# Altium schematic sheet 19_ATK_RK3588S_MIPI.SchDoc
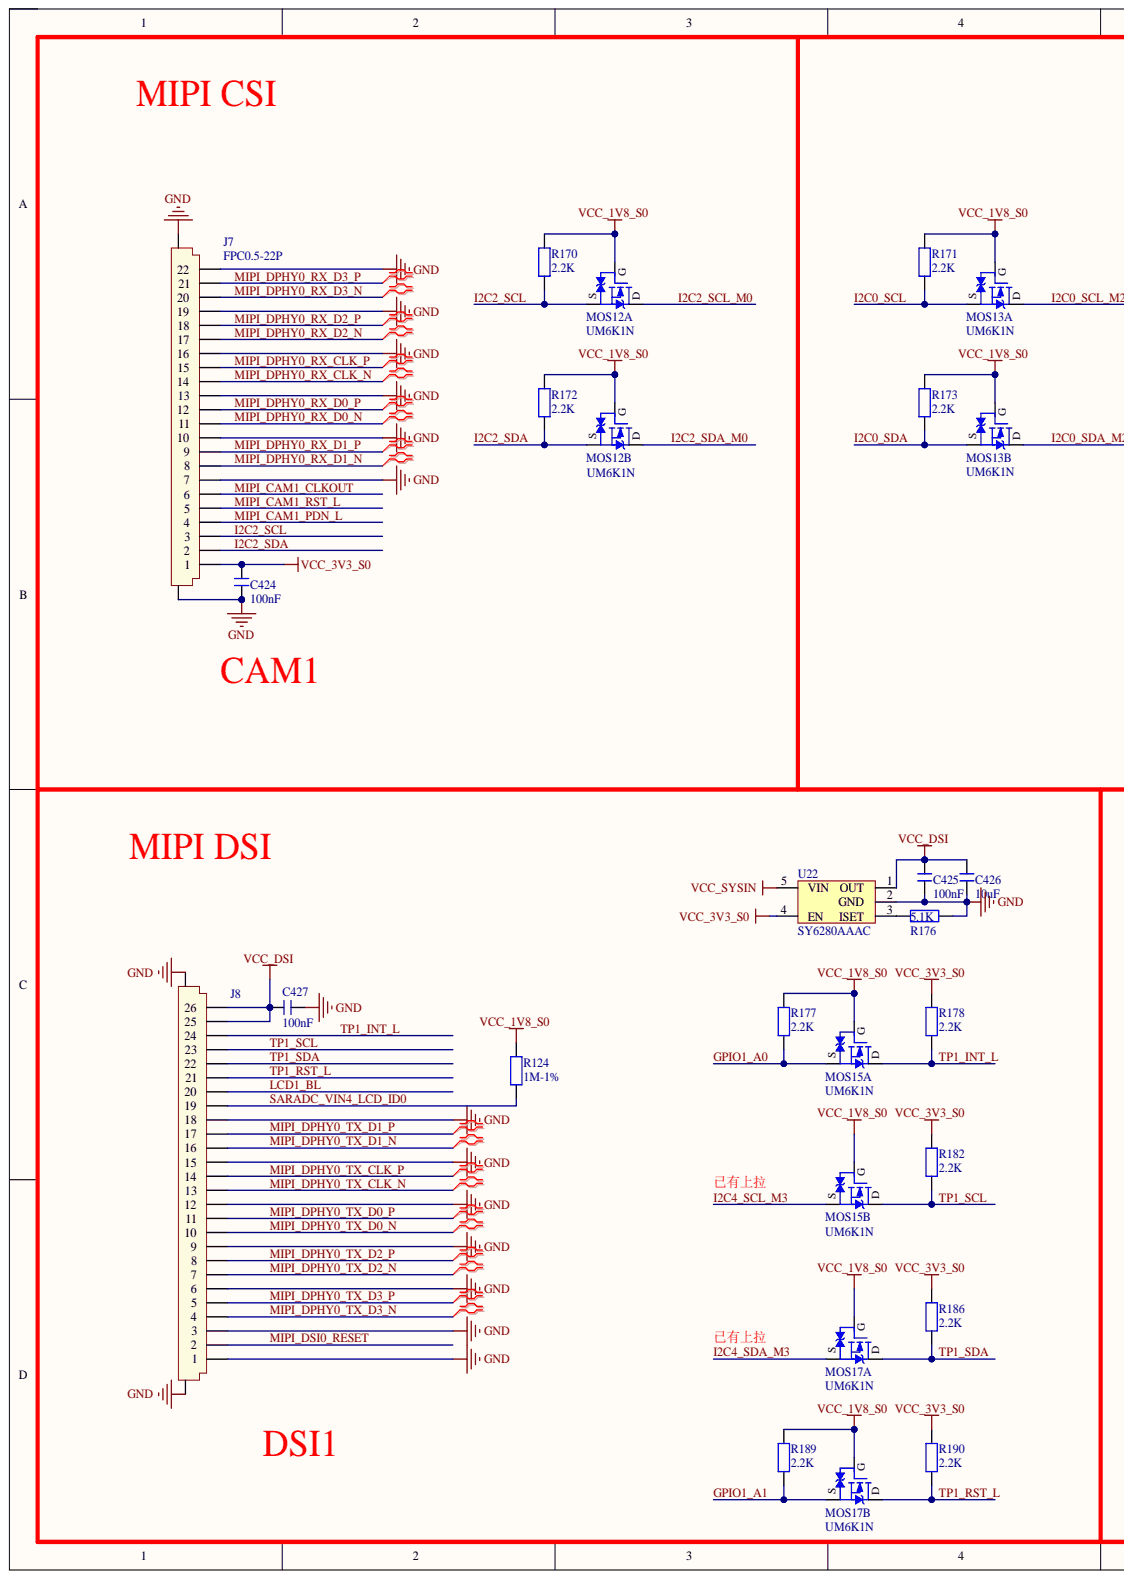
[diagram p0: 19_ATK_RK3588S_MIPI.SchDoc, left]
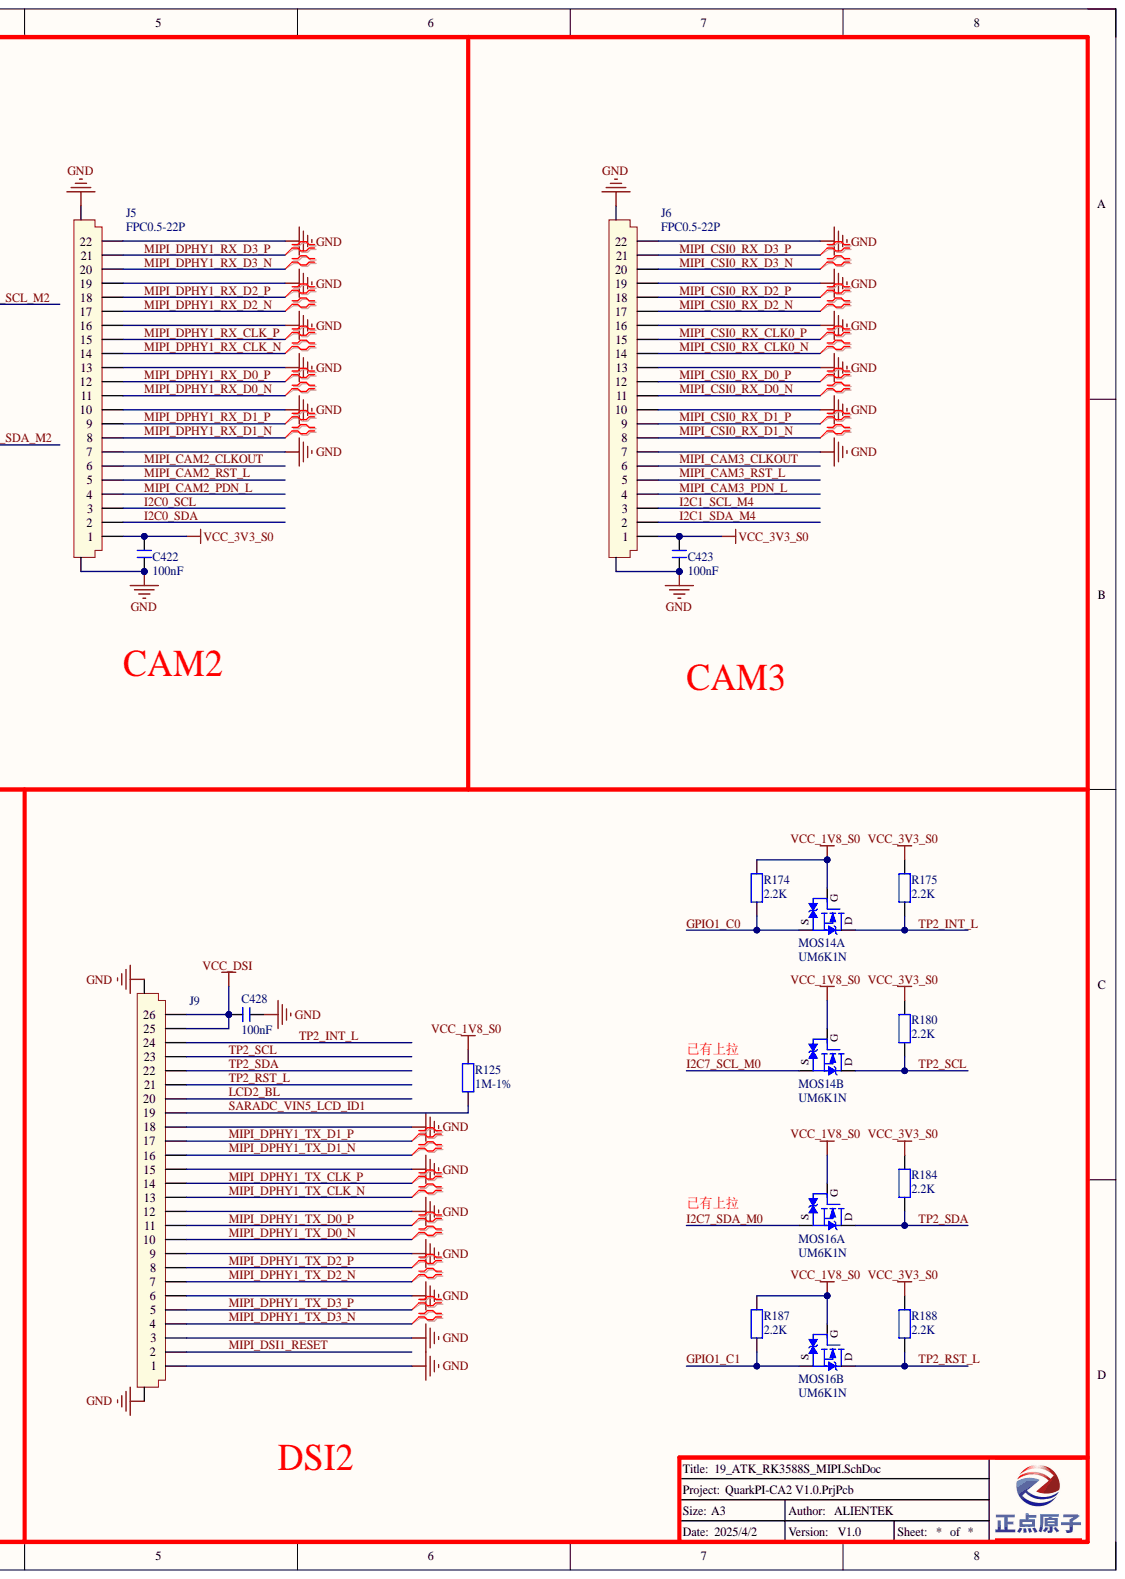
[diagram p1: 19_ATK_RK3588S_MIPI.SchDoc, right]

## Parts
C422  100nF  [C 0201]
C423  100nF  [C 0201]
C424  100nF  [C 0201]
C425  100nF  [C 0201]
C426  10uF  [C 0402]
C427  100nF  [C 0201]
C428  100nF  [C 0201]
J5  FPC0.5-22P  [FPC 0.5 22P V-S]
J6  FPC0.5-22P  [FPC 0.5 22P V-S]
J7  FPC0.5-22P  [FPC 0.5 22P V-S]
J8  FPC0.5-26P  [FPC 0.5 26P H-S]  (value not drawn)
J9  FPC0.5-26P  [FPC 0.5 26P H-S]  (value not drawn)
MOS12  UM6K1N  [SOT363-6L]  (parts A,B)
MOS13  UM6K1N  [SOT363-6L]  (parts A,B)
MOS14  UM6K1N  [SOT363-6L]  (parts A,B)
MOS15  UM6K1N  [SOT363-6L]  (parts A,B)
MOS16  UM6K1N  [SOT363-6L]  (parts A,B)
MOS17  UM6K1N  [SOT363-6L]  (parts A,B)
R124  1M-1%  [R 0402]
R125  1M-1%  [R 0402]
R170  2.2K  [R 0201]
R171  2.2K  [R 0201]
R172  2.2K  [R 0201]
R173  2.2K  [R 0201]
R174  2.2K  [R 0201]
R175  2.2K  [R 0201]
R176  5.1K  [R 0402]
R177  2.2K  [R 0201]
R178  2.2K  [R 0201]
R180  2.2K  [R 0201]
R182  2.2K  [R 0201]
R184  2.2K  [R 0201]
R186  2.2K  [R 0201]
R187  2.2K  [R 0201]
R188  2.2K  [R 0201]
R189  2.2K  [R 0201]
R190  2.2K  [R 0201]
U22  SY6280AAAC  [SOT23-5N]

## Nets
GND: C422.1 C423.1 C424.1 C425.2 C426.2 C427.2 C428.2 J5.0 J5.7 J5.10 J5.13 J5.16 J5.19 J5.22 J6.0 J6.7 J6.10 J6.13 J6.16 J6.19 J6.22 J7.0 J7.7 J7.10 J7.13 J7.16 J7.19 J7.22 J8.0 J8.1 J8.3 J8.6 J8.9 J8.12 J8.15 J8.18 J9.0 J9.1 J9.3 J9.6 J9.9 J9.12 J9.15 J9.18 R176.1 U22.2(GND)
VCC_1V8_S0: MOS12.2 MOS12.5 MOS13.2 MOS13.5 MOS14.2 MOS14.5 MOS15.2 MOS15.5 MOS16.2 MOS16.5 MOS17.2 MOS17.5 R124.2 R125.2 R170.2 R171.2 R172.2 R173.2 R174.2 R177.2 R187.2 R189.2
VCC_3V3_S0: C422.2 C423.2 C424.2 J5.1 J6.1 J7.1 R175.2 R178.2 R180.2 R182.2 R184.2 R186.2 R188.2 R190.2 U22.4(EN)
VCC_DSI: C425.1 C426.1 C427.1 C428.1 J8.25 J8.26 J9.25 J9.26 U22.1(OUT)
VCC_SYSIN: U22.5(VIN)
GPIO1_A0: MOS15.1 R177.1
GPIO1_A1: MOS17.4 R189.1
GPIO1_C0: MOS14.1 R174.1
GPIO1_C1: MOS16.4 R187.1
I2C0_SCL: J5.3 MOS13.1 R171.1
I2C0_SCL_M2: MOS13.6
I2C0_SDA: J5.2 MOS13.4 R173.1
I2C0_SDA_M2: MOS13.3
I2C1_SCL_M4: J6.3
I2C1_SDA_M4: J6.2
I2C2_SCL: J7.3 MOS12.1 R170.1
I2C2_SCL_M0: MOS12.6
I2C2_SDA: J7.2 MOS12.4 R172.1
I2C2_SDA_M0: MOS12.3
I2C4_SCL_M3: MOS15.4
I2C4_SDA_M3: MOS17.1
I2C7_SCL_M0: MOS14.4
I2C7_SDA_M0: MOS16.1
LCD1_BL: J8.20
LCD2_BL: J9.20
MIPI_CAM1_CLKOUT: J7.6
MIPI_CAM1_PDN_L: J7.4
MIPI_CAM1_RST_L: J7.5
MIPI_CAM2_CLKOUT: J5.6
MIPI_CAM2_PDN_L: J5.4
MIPI_CAM2_RST_L: J5.5
MIPI_CAM3_CLKOUT: J6.6
MIPI_CAM3_PDN_L: J6.4
MIPI_CAM3_RST_L: J6.5
MIPI_CSI0_RX_CLK0_N: J6.14
MIPI_CSI0_RX_CLK0_P: J6.15
MIPI_CSI0_RX_D0_N: J6.11
MIPI_CSI0_RX_D0_P: J6.12
MIPI_CSI0_RX_D1_N: J6.8
MIPI_CSI0_RX_D1_P: J6.9
MIPI_CSI0_RX_D2_N: J6.17
MIPI_CSI0_RX_D2_P: J6.18
MIPI_CSI0_RX_D3_N: J6.20
MIPI_CSI0_RX_D3_P: J6.21
MIPI_DPHY0_RX_CLK_N: J7.14
MIPI_DPHY0_RX_CLK_P: J7.15
MIPI_DPHY0_RX_D0_N: J7.11
MIPI_DPHY0_RX_D0_P: J7.12
MIPI_DPHY0_RX_D1_N: J7.8
MIPI_DPHY0_RX_D1_P: J7.9
MIPI_DPHY0_RX_D2_N: J7.17
MIPI_DPHY0_RX_D2_P: J7.18
MIPI_DPHY0_RX_D3_N: J7.20
MIPI_DPHY0_RX_D3_P: J7.21
MIPI_DPHY0_TX_CLK_N: J8.13
MIPI_DPHY0_TX_CLK_P: J8.14
MIPI_DPHY0_TX_D0_N: J8.10
MIPI_DPHY0_TX_D0_P: J8.11
MIPI_DPHY0_TX_D1_N: J8.16
MIPI_DPHY0_TX_D1_P: J8.17
MIPI_DPHY0_TX_D2_N: J8.7
MIPI_DPHY0_TX_D2_P: J8.8
MIPI_DPHY0_TX_D3_N: J8.4
MIPI_DPHY0_TX_D3_P: J8.5
MIPI_DPHY1_RX_CLK_N: J5.14
MIPI_DPHY1_RX_CLK_P: J5.15
MIPI_DPHY1_RX_D0_N: J5.11
MIPI_DPHY1_RX_D0_P: J5.12
MIPI_DPHY1_RX_D1_N: J5.8
MIPI_DPHY1_RX_D1_P: J5.9
MIPI_DPHY1_RX_D2_N: J5.17
MIPI_DPHY1_RX_D2_P: J5.18
MIPI_DPHY1_RX_D3_N: J5.20
MIPI_DPHY1_RX_D3_P: J5.21
MIPI_DPHY1_TX_CLK_N: J9.13
MIPI_DPHY1_TX_CLK_P: J9.14
MIPI_DPHY1_TX_D0_N: J9.10
MIPI_DPHY1_TX_D0_P: J9.11
MIPI_DPHY1_TX_D1_N: J9.16
MIPI_DPHY1_TX_D1_P: J9.17
MIPI_DPHY1_TX_D2_N: J9.7
MIPI_DPHY1_TX_D2_P: J9.8
MIPI_DPHY1_TX_D3_N: J9.4
MIPI_DPHY1_TX_D3_P: J9.5
MIPI_DSI0_RESET: J8.2
MIPI_DSI1_RESET: J9.2
SARADC_VIN4_LCD_ID0: J8.19 R124.1
SARADC_VIN5_LCD_ID1: J9.19 R125.1
TP1_INT_L: J8.24 MOS15.6 R178.1
TP1_RST_L: J8.21 MOS17.3 R190.1
TP1_SCL: J8.23 MOS15.3 R182.1
TP1_SDA: J8.22 MOS17.6 R186.1
TP2_INT_L: J9.24 MOS14.6 R175.1
TP2_RST_L: J9.21 MOS16.3 R188.1
TP2_SCL: J9.23 MOS14.3 R180.1
TP2_SDA: J9.22 MOS16.6 R184.1
NetR176_2: R176.2 U22.3(ISET)

## Library data of the parts
C422-C428 = C: 无极性电容; BOM名称: 无极性贴片电容; 版权归属: 正点原子; Component Kind: Standard; Library Name: 正点原子封装库V1.0.IntLib; 学习资源: www.openedv.com
J5-J7 = FPC-22P: FPC连接器; Parameter: 接口器件; 版权归属: 正点原子; BOM名称: FPC连接器; Component Kind: Standard; 学习资源: www.openedv.com; Library Name: AtomPi-CA1 V1.1.IntLib
J8 J9 = FPC-26P: FPC连接器; Parameter: 接口器件; 版权归属: 正点原子; BOM名称: FPC连接器; Component Kind: Standard; 学习资源: www.openedv.com; Library Name: AtomPi-CA1 V1.1.IntLib
MOS12-MOS17 = DUAL NMOS: NMOS; BOM名称: N沟道场效应管; Parameter: MOS管; 版权归属: 正点原子; Component Kind: Standard; Library Name: MOS管.SchLib; 学习资源: www.openedv.com
R124 R125 R170-R178 R180 R182 R184 R186-R190 = R: 电阻; BOM名称: 贴片电阻; 版权归属: 正点原子; Component Kind: Standard; Library Name: 正点原子封装库V1.0.IntLib; Parameter: 电阻; 学习资源: www.openedv.com
U22 = MT9700HT5: 功率开关(5.5V-2A); BOM名称: 稳压芯片; Parameter: 芯片; 版权归属: 正点原子; Component Kind: Standard; Library Name: 正点原子封装库V1.0.IntLib; 学习资源: www.openedv.com
